# Altium schematic sheet Nixie_Clock_Tube.SchDoc
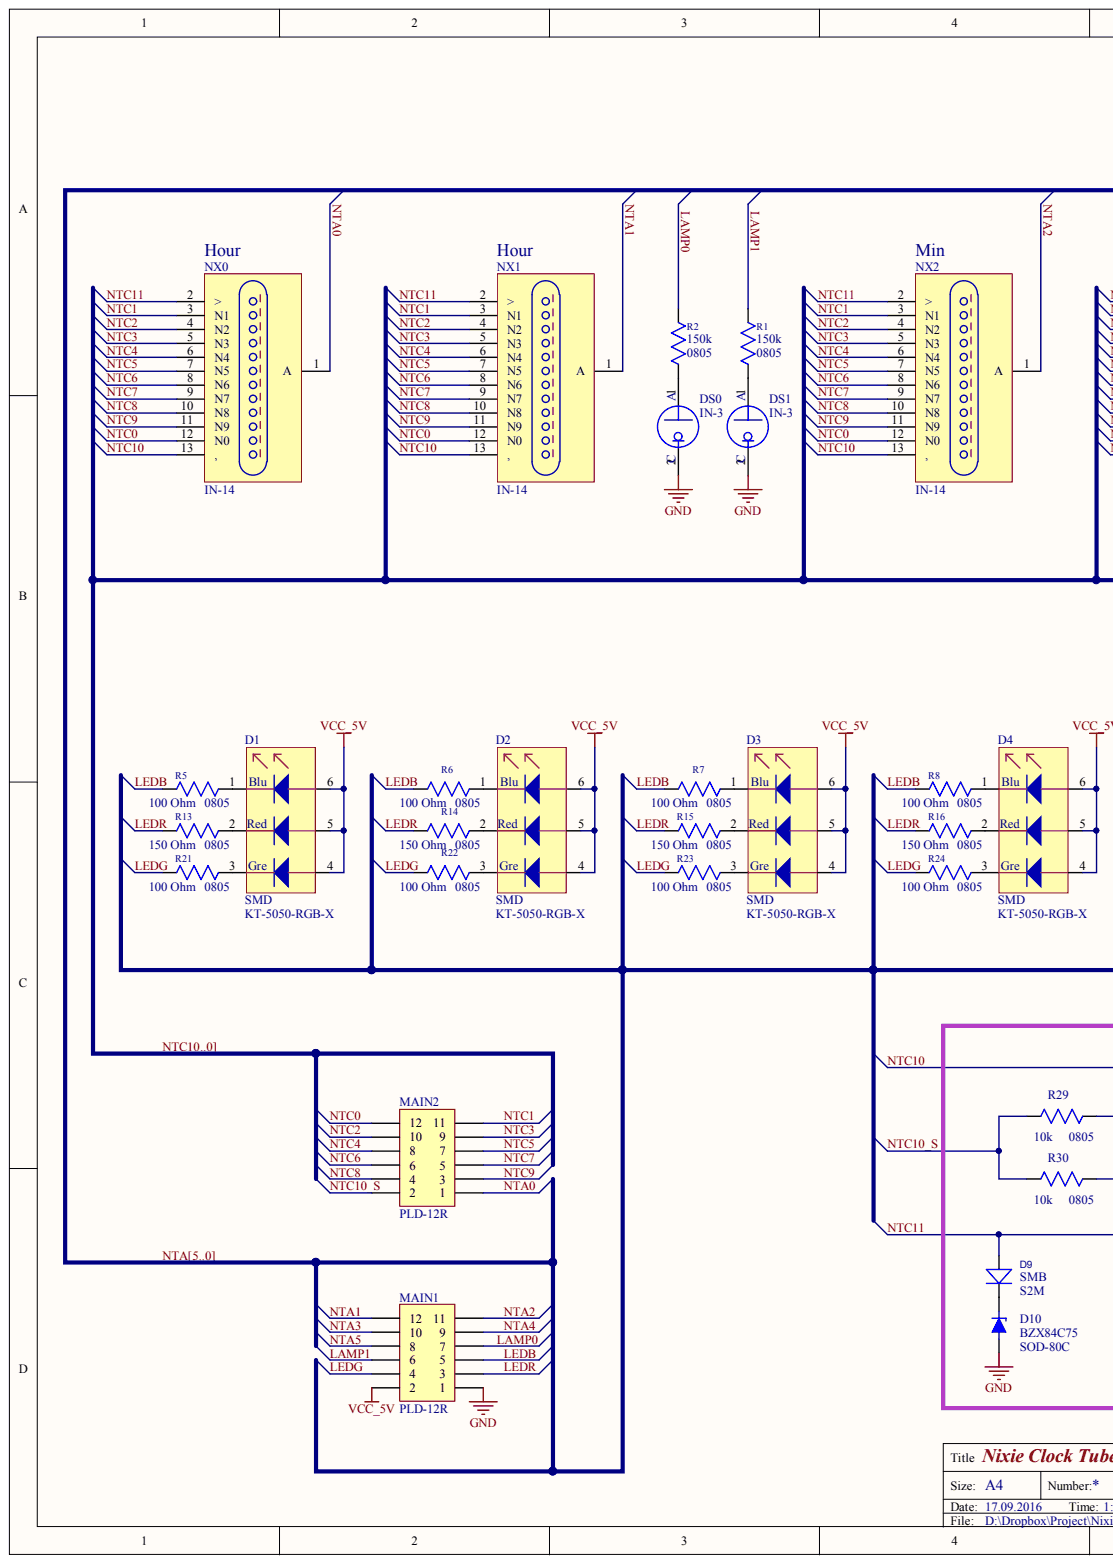
[diagram p0: Nixie_Clock_Tube.SchDoc, left]
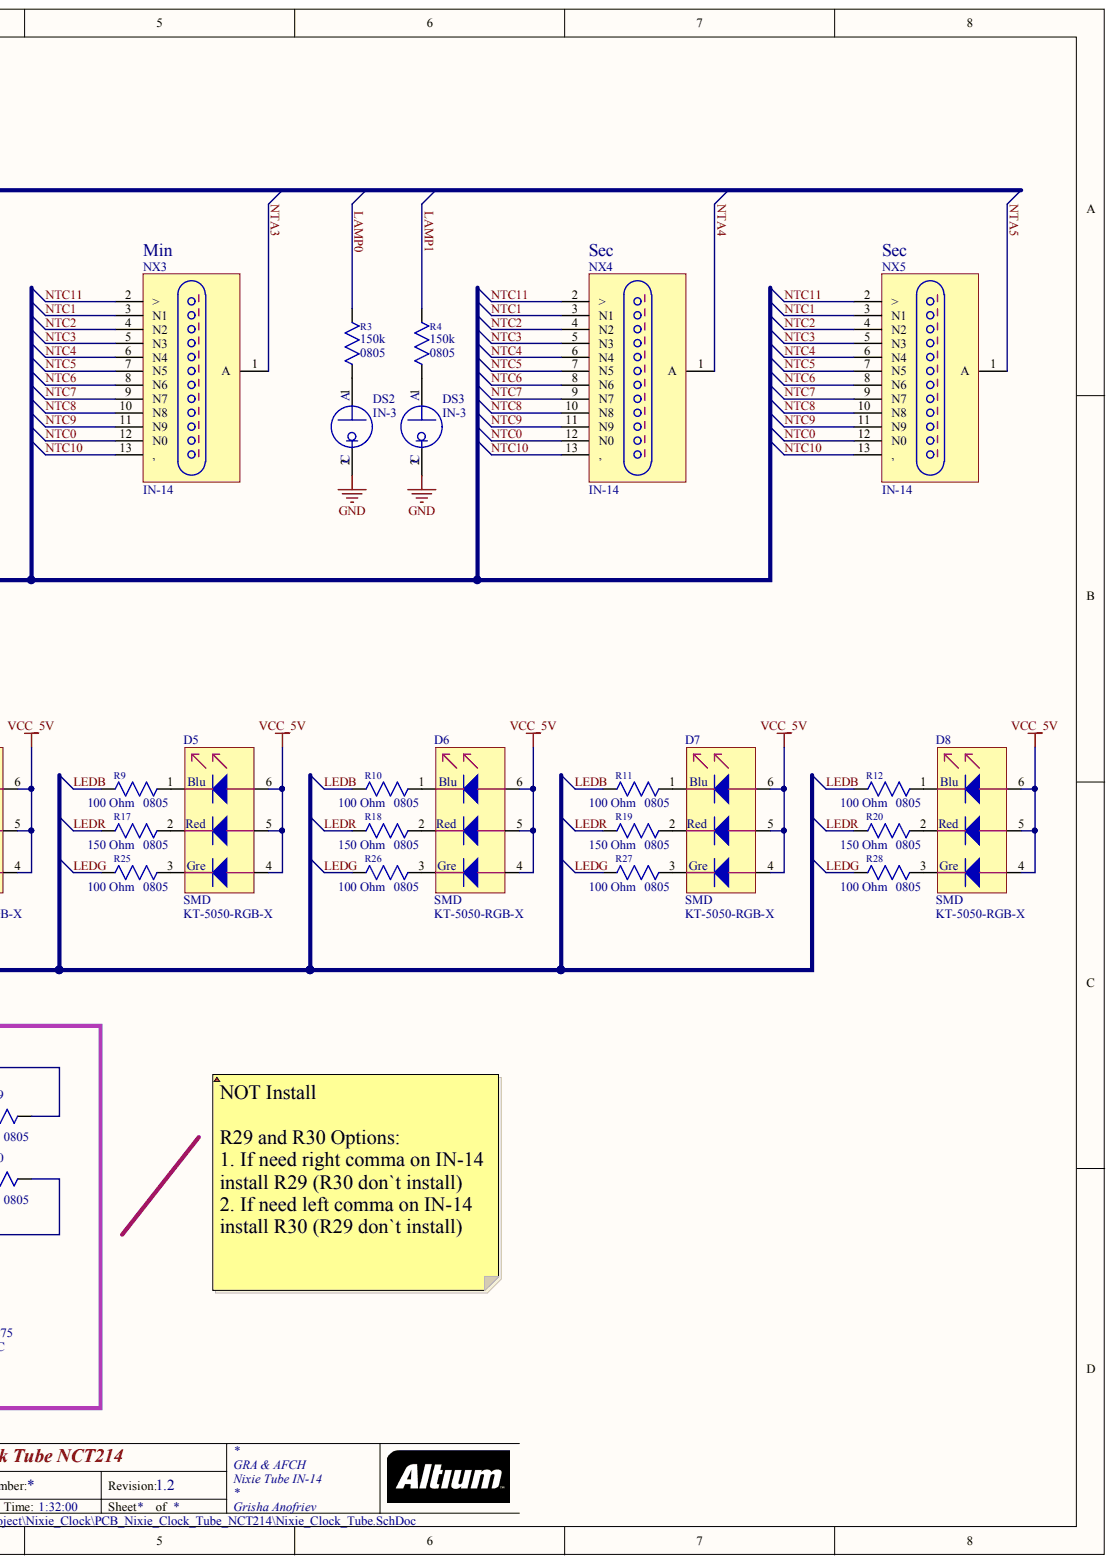
[diagram p1: Nixie_Clock_Tube.SchDoc, right]

## Parts
D1  value KT-5050-RGB-X  PackageReference: SMD  [LED_RGB_5050]  (hidden comment: LED_5050RGB)
D2  value KT-5050-RGB-X  PackageReference: SMD  [LED_RGB_5050]  (hidden comment: LED_5050RGB)
D3  value KT-5050-RGB-X  PackageReference: SMD  [LED_RGB_5050]  (hidden comment: LED_5050RGB)
D4  value KT-5050-RGB-X  PackageReference: SMD  [LED_RGB_5050]  (hidden comment: LED_5050RGB)
D5  value KT-5050-RGB-X  PackageReference: SMD  [LED_RGB_5050]  (hidden comment: LED_5050RGB)
D6  value KT-5050-RGB-X  PackageReference: SMD  [LED_RGB_5050]  (hidden comment: LED_5050RGB)
D7  value KT-5050-RGB-X  PackageReference: SMD  [LED_RGB_5050]  (hidden comment: LED_5050RGB)
D8  value KT-5050-RGB-X  PackageReference: SMD  [LED_RGB_5050]  (hidden comment: LED_5050RGB)
D9  S2M  Package: SMB  [DO-214AA (SMB)]
D10  BZX84C75  PackageReference: SOD-80C  [SOD80/MLL34]
DS0  value IN-3  [Lamp_Con_Hole]  (hidden comment: Lamp_Nixie)
DS1  value IN-3  [Lamp_Con_Hole]  (hidden comment: Lamp_Nixie)
DS2  value IN-3  [Lamp_Con_Hole]  (hidden comment: Lamp_Nixie)
DS3  value IN-3  [Lamp_Con_Hole]  (hidden comment: Lamp_Nixie)
MAIN1  PLD-12R  [PLD-12-3D _Norm]
MAIN2  PLD-12R  [PLD-12-3D _Norm]
NX0  value IN-14  [IN-14]  (hidden comment: IN-14_Tube)
NX1  value IN-14  [IN-14]  (hidden comment: IN-14_Tube)
NX2  value IN-14  [IN-14]  (hidden comment: IN-14_Tube)
NX3  value IN-14  [IN-14]  (hidden comment: IN-14_Tube)
NX4  value IN-14  [IN-14]  (hidden comment: IN-14_Tube)
NX5  value IN-14  [IN-14]  (hidden comment: IN-14_Tube)
R1  150k 0805  value 150k  PackageReference: 0805  [R_0805]
R2  150k 0805  value 150k  PackageReference: 0805  [R_0805]
R3  150k 0805  value 150k  PackageReference: 0805  [R_0805]
R4  150k 0805  value 150k  PackageReference: 0805  [R_0805]
R5  100 Ohm 0805  value 100 Ohm  PackageReference: 0805  [R_0805]
R6  100 Ohm 0805  value 100 Ohm  PackageReference: 0805  [R_0805]
R7  100 Ohm 0805  value 100 Ohm  PackageReference: 0805  [R_0805]
R8  100 Ohm 0805  value 100 Ohm  PackageReference: 0805  [R_0805]
R9  100 Ohm 0805  value 100 Ohm  PackageReference: 0805  [R_0805]
R10  100 Ohm 0805  value 100 Ohm  PackageReference: 0805  [R_0805]
R11  100 Ohm 0805  value 100 Ohm  PackageReference: 0805  [R_0805]
R12  100 Ohm 0805  value 100 Ohm  PackageReference: 0805  [R_0805]
R13  150 Ohm 0805  value 150 Ohm  PackageReference: 0805  [R_0805]
R14  150 Ohm 0805  value 150 Ohm  PackageReference: 0805  [R_0805]
R15  150 Ohm 0805  value 150 Ohm  PackageReference: 0805  [R_0805]
R16  150 Ohm 0805  value 150 Ohm  PackageReference: 0805  [R_0805]
R17  150 Ohm 0805  value 150 Ohm  PackageReference: 0805  [R_0805]
R18  150 Ohm 0805  value 150 Ohm  PackageReference: 0805  [R_0805]
R19  150 Ohm 0805  value 150 Ohm  PackageReference: 0805  [R_0805]
R20  150 Ohm 0805  value 150 Ohm  PackageReference: 0805  [R_0805]
R21  100 Ohm 0805  value 100 Ohm  PackageReference: 0805  [R_0805]
R22  100 Ohm 0805  value 100 Ohm  PackageReference: 0805  [R_0805]
R23  100 Ohm 0805  value 100 Ohm  PackageReference: 0805  [R_0805]
R24  100 Ohm 0805  value 100 Ohm  PackageReference: 0805  [R_0805]
R25  100 Ohm 0805  value 100 Ohm  PackageReference: 0805  [R_0805]
R26  100 Ohm 0805  value 100 Ohm  PackageReference: 0805  [R_0805]
R27  100 Ohm 0805  value 100 Ohm  PackageReference: 0805  [R_0805]
R28  100 Ohm 0805  value 100 Ohm  PackageReference: 0805  [R_0805]
R29  10k 0805  value 10k  PackageReference: 0805  [R_0805]
R30  10k 0805  value 10k  PackageReference: 0805  [R_0805]

## Nets
GND: D10.1 DS0.2 DS1.2 DS2.2 DS3.2 MAIN1.1
VCC_5V: D1.4 D1.5 D1.6 D2.4 D2.5 D2.6 D3.4 D3.5 D3.6 D4.4 D4.5 D4.6 D5.4 D5.5 D5.6 D6.4 D6.5 D6.6 D7.4 D7.5 D7.6 D8.4 D8.5 D8.6 MAIN1.2
LAMP0: MAIN1.7 R2.2 R3.2
LAMP1: MAIN1.6 R1.2 R4.2
LEDB: MAIN1.5 R5.2 R6.2 R7.2 R8.2 R9.2 R10.2 R11.2 R12.2
LEDG: MAIN1.4 R21.2 R22.2 R23.2 R24.2 R25.2 R26.2 R27.2 R28.2
LEDR: MAIN1.3 R13.2 R14.2 R15.2 R16.2 R17.2 R18.2 R19.2 R20.2
NTA0: MAIN2.1 NX0.1(A)  [also labelled NTA]
NTA1: MAIN1.12 NX1.1(A)  [also labelled NTA]
NTA2: MAIN1.11 NX2.1(A)  [also labelled NTA]
NTA3: MAIN1.10 NX3.1(A)  [also labelled NTA]
NTA4: MAIN1.9 NX4.1(A)  [also labelled NTA]
NTA5: MAIN1.8 NX5.1(A)  [also labelled NTA]
NTC0: MAIN2.12 NX0.12(N0) NX1.12(N0) NX2.12(N0) NX3.12(N0) NX4.12(N0) NX5.12(N0)
NTC1: MAIN2.11 NX0.3(N1) NX1.3(N1) NX2.3(N1) NX3.3(N1) NX4.3(N1) NX5.3(N1)
NTC2: MAIN2.10 NX0.4(N2) NX1.4(N2) NX2.4(N2) NX3.4(N2) NX4.4(N2) NX5.4(N2)
NTC3: MAIN2.9 NX0.5(N3) NX1.5(N3) NX2.5(N3) NX3.5(N3) NX4.5(N3) NX5.5(N3)
NTC4: MAIN2.8 NX0.6(N4) NX1.6(N4) NX2.6(N4) NX3.6(N4) NX4.6(N4) NX5.6(N4)
NTC5: MAIN2.7 NX0.7(N5) NX1.7(N5) NX2.7(N5) NX3.7(N5) NX4.7(N5) NX5.7(N5)
NTC6: MAIN2.6 NX0.8(N6) NX1.8(N6) NX2.8(N6) NX3.8(N6) NX4.8(N6) NX5.8(N6)
NTC7: MAIN2.5 NX0.9(N7) NX1.9(N7) NX2.9(N7) NX3.9(N7) NX4.9(N7) NX5.9(N7)
NTC8: MAIN2.4 NX0.10(N8) NX1.10(N8) NX2.10(N8) NX3.10(N8) NX4.10(N8) NX5.10(N8)
NTC9: MAIN2.3 NX0.11(N9) NX1.11(N9) NX2.11(N9) NX3.11(N9) NX4.11(N9) NX5.11(N9)
NTC10: NX0.13(,) NX1.13(,) NX2.13(,) NX3.13(,) NX4.13(,) NX5.13(,) R29.1
NTC10_S: MAIN2.2 R29.2 R30.2
NTC11: D9.1 NX0.2(>) NX1.2(>) NX2.2(>) NX3.2(>) NX4.2(>) NX5.2(>) R30.1
NetD1_1: D1.1 R5.1
NetD1_2: D1.2 R13.1
NetD1_3: D1.3 R21.1
NetD2_1: D2.1 R6.1
NetD2_2: D2.2 R14.1
NetD2_3: D2.3 R22.1
NetD3_1: D3.1 R7.1
NetD3_2: D3.2 R15.1
NetD3_3: D3.3 R23.1
NetD4_1: D4.1 R8.1
NetD4_2: D4.2 R16.1
NetD4_3: D4.3 R24.1
NetD5_1: D5.1 R9.1
NetD5_2: D5.2 R17.1
NetD5_3: D5.3 R25.1
NetD6_1: D6.1 R10.1
NetD6_2: D6.2 R18.1
NetD6_3: D6.3 R26.1
NetD7_1: D7.1 R11.1
NetD7_2: D7.2 R19.1
NetD7_3: D7.3 R27.1
NetD8_1: D8.1 R12.1
NetD8_2: D8.2 R20.1
NetD8_3: D8.3 R28.1
NetD9_2: D9.2 D10.2
NetDS0_1: DS0.1 R2.1
NetDS1_1: DS1.1 R1.1
NetDS2_1: DS2.1 R3.1
NetDS3_1: DS3.1 R4.1
NTC10..0]:

## Library data of the parts
D1-D8 = LED_5050RGB: Default Diode; Component Kind: Standard; Library Name: Nixie_Clock_Tube.SchLib
D9 = S2M: Diode S2M SMB; Manufacturer: Any; Component Kind: Standard; Value: S2M; Library Name: Nixie_Clock_Tube.SchLib
D10 = BZX84C75: 0,5W 75V SOD-80C; Manafacturer: Any; Component Kind: Standard; Price: 0.044; Signal Integrity: D; Simulation: BZX84C5V1; Value: BZX84C75; Library Name: Nixie_Clock_Tube.SchLib
DS0-DS3 = Lamp_Nixie: Neon Lamp; Component Kind: Standard; PackageReference: PIN2; Library Name: Nixie_Clock_Tube.SchLib
MAIN1 MAIN2 = PLD-12R: Header, 6-Pin, Dual row; Manufacturer: Any; Component Kind: Standard; PackageReference: PLD-12R; Library Name: Nixie_Clock_Tube.SchLib
R1-R30 = R0805: Resistor; Manufacturer: Any; Component Kind: Standard; Library Name: Nixie_Clock_Tube.SchLib
NX0-NX5 = IN-14_Tube: Component Kind: Standard; Library Name: Nixie_Clock_Tube.SchLib
